# Altium schematic sheet Top Level.SchDoc
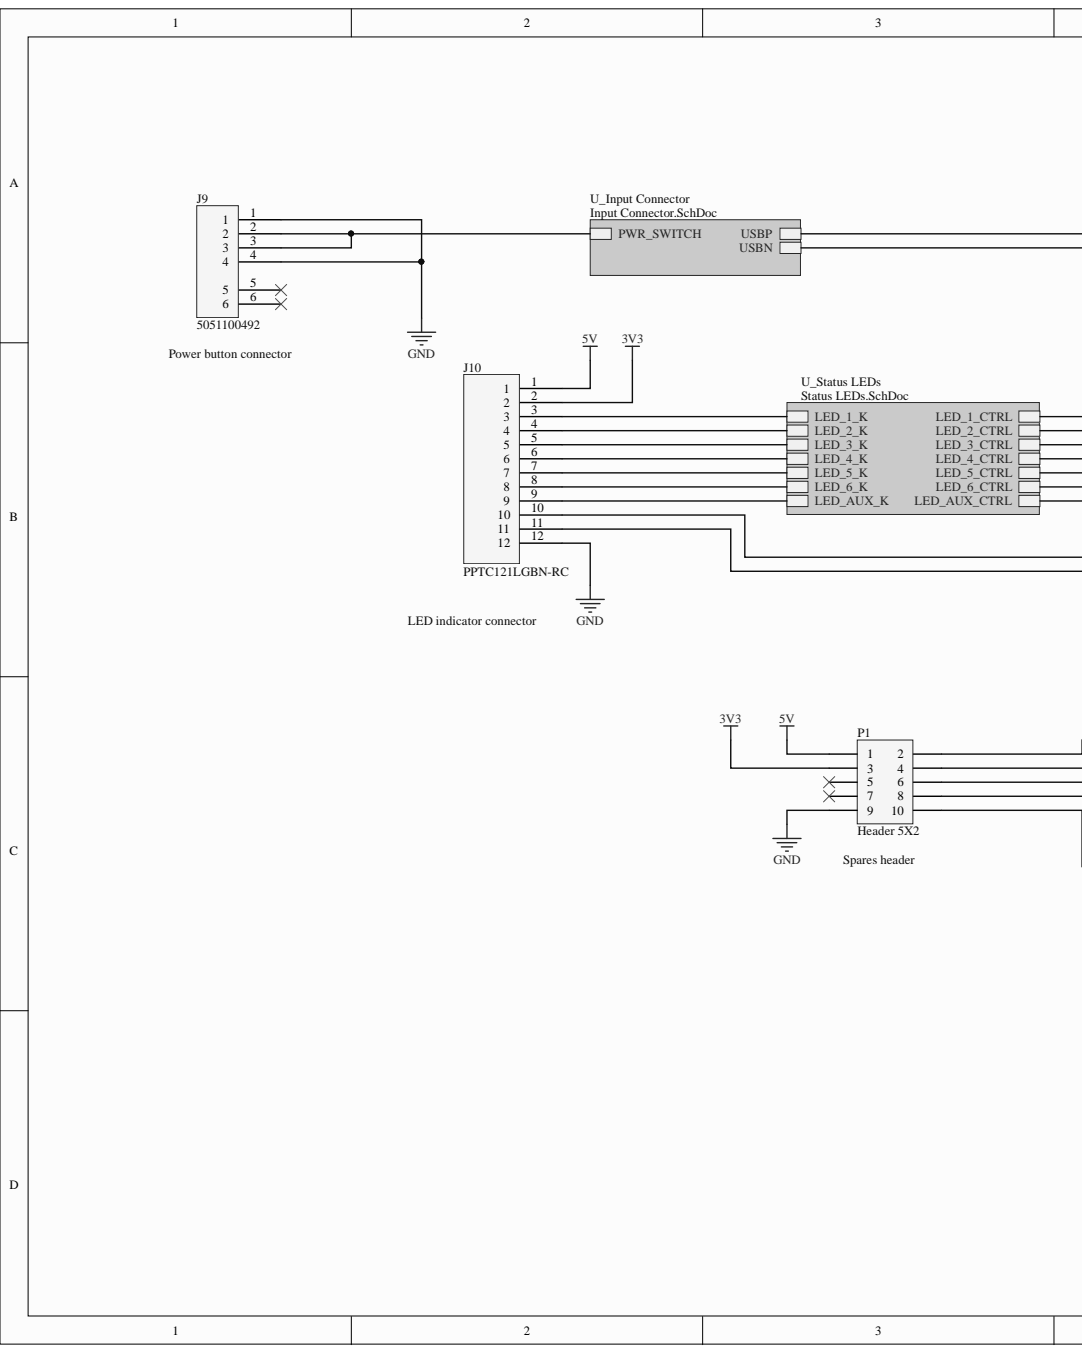
[diagram p0: Top Level.SchDoc, left]
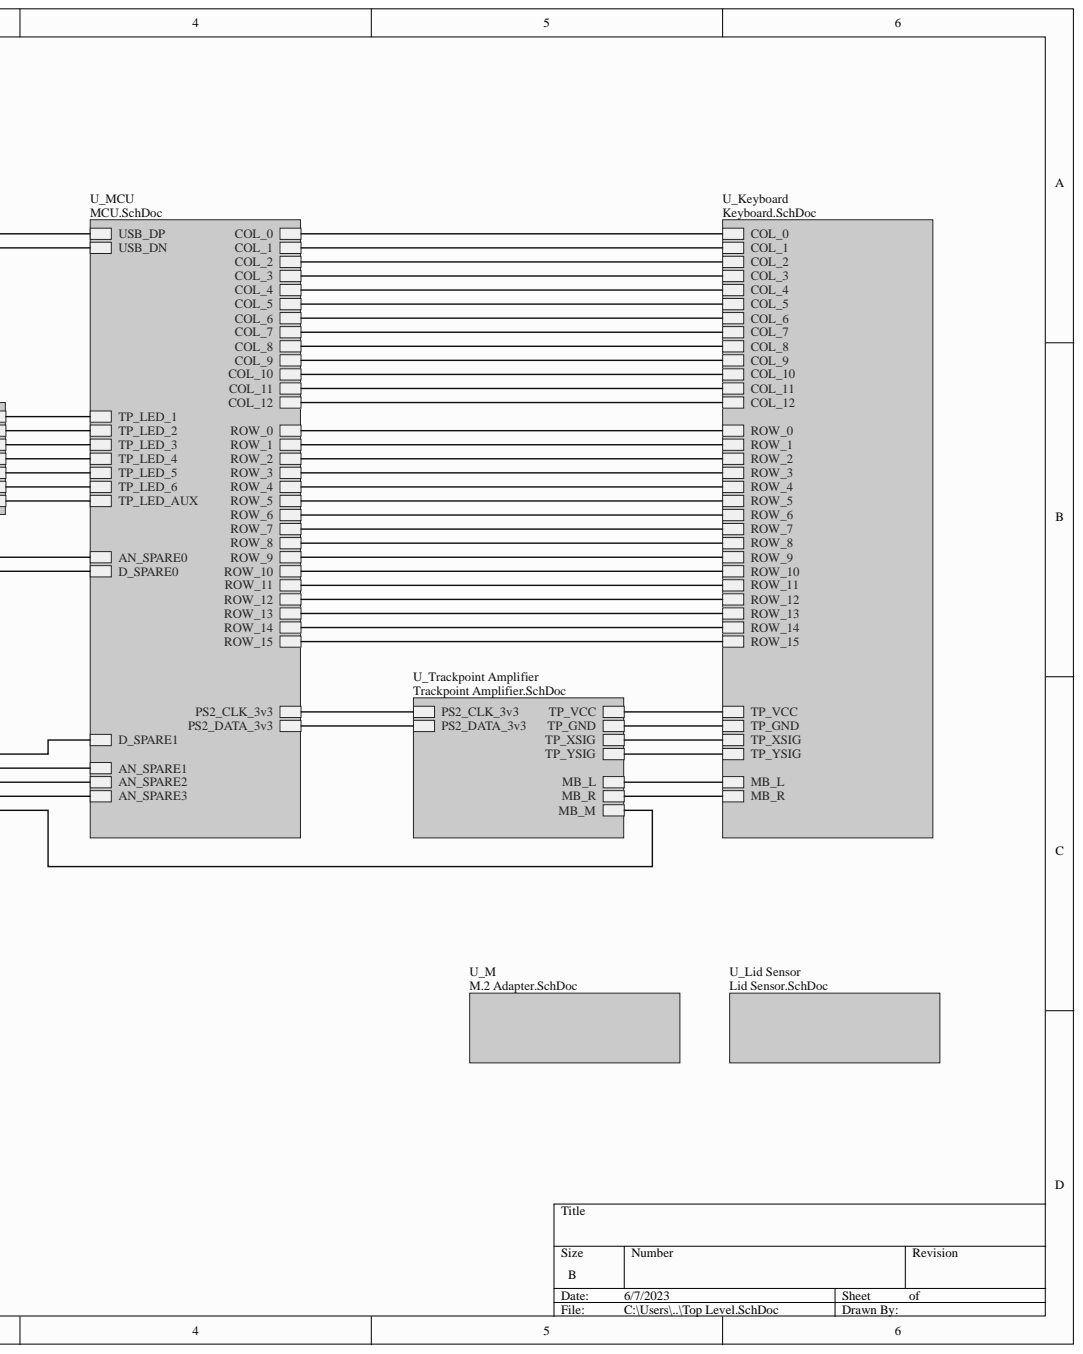
[diagram p1: Top Level.SchDoc, right]

## Parts
J9  5051100492  [5051100492]
J10  PPTC121LGBN-RC  [PPTC121LGBN-RC]
P1  Header 5X2  [HDR2X5]

## Nets
3V3: J10.2 P1.3
5V: J10.1 P1.1
GND: J9.1 J9.4 J10.12 P1.9
NetJ9_2: J9.2 J9.3
NetJ9_5: J9.5
NetJ9_6: J9.6
NetJ10_3: J10.3
NetJ10_4: J10.4
NetJ10_5: J10.5
NetJ10_6: J10.6
NetJ10_7: J10.7
NetJ10_8: J10.8
NetJ10_9: J10.9
NetJ10_10: J10.10
NetJ10_11: J10.11
NetP1_2: P1.2
NetP1_4: P1.4
NetP1_5: P1.5
NetP1_6: P1.6
NetP1_7: P1.7
NetP1_8: P1.8
NetP1_10: P1.10
COL_0:
COL_1:
COL_2:
COL_3:
COL_4:
COL_5:
COL_6:
COL_7:
COL_8:
COL_9:
COL_10:
COL_11:
COL_12:
MB_L:
MB_R:
PS2_CLK_3v3:
PS2_DATA_3v3:
ROW_0:
ROW_1:
ROW_2:
ROW_3:
ROW_4:
ROW_5:
ROW_6:
ROW_7:
ROW_8:
ROW_9:
ROW_10:
ROW_11:
ROW_12:
ROW_13:
ROW_14:
ROW_15:
TP_GND:
TP_LED_1:
TP_LED_2:
TP_LED_3:
TP_LED_4:
TP_LED_5:
TP_LED_6:
TP_LED_AUX:
TP_VCC:
TP_XSIG:
TP_YSIG:
USBN:
USBP:

## Library data of the parts
J9 = 5051100492: CONN FPC BOTTOM 4POS .5MM R/A; Component Kind: Standard; Library Name: KBuchka-IntLib.SchLib
J10 = PPTC121LGBN-RC: CONN HDR 12POS 0.1 TIN PCB R/A; Component Kind: Standard; Library Name: KBuchka-IntLib.SchLib
P1 = Header 5X2: Header, 5-Pin, Dual row; Component Kind: Standard; LatestRevisionDate: 17-Jul-2002; LatestRevisionNote: Re-released for DXP Platform.; Library Name: Miscellaneous Connectors.IntLib; Publisher: Altium Limited
